# Altium schematic sheet ControllerBoard.SchDoc(ControllerBoard)
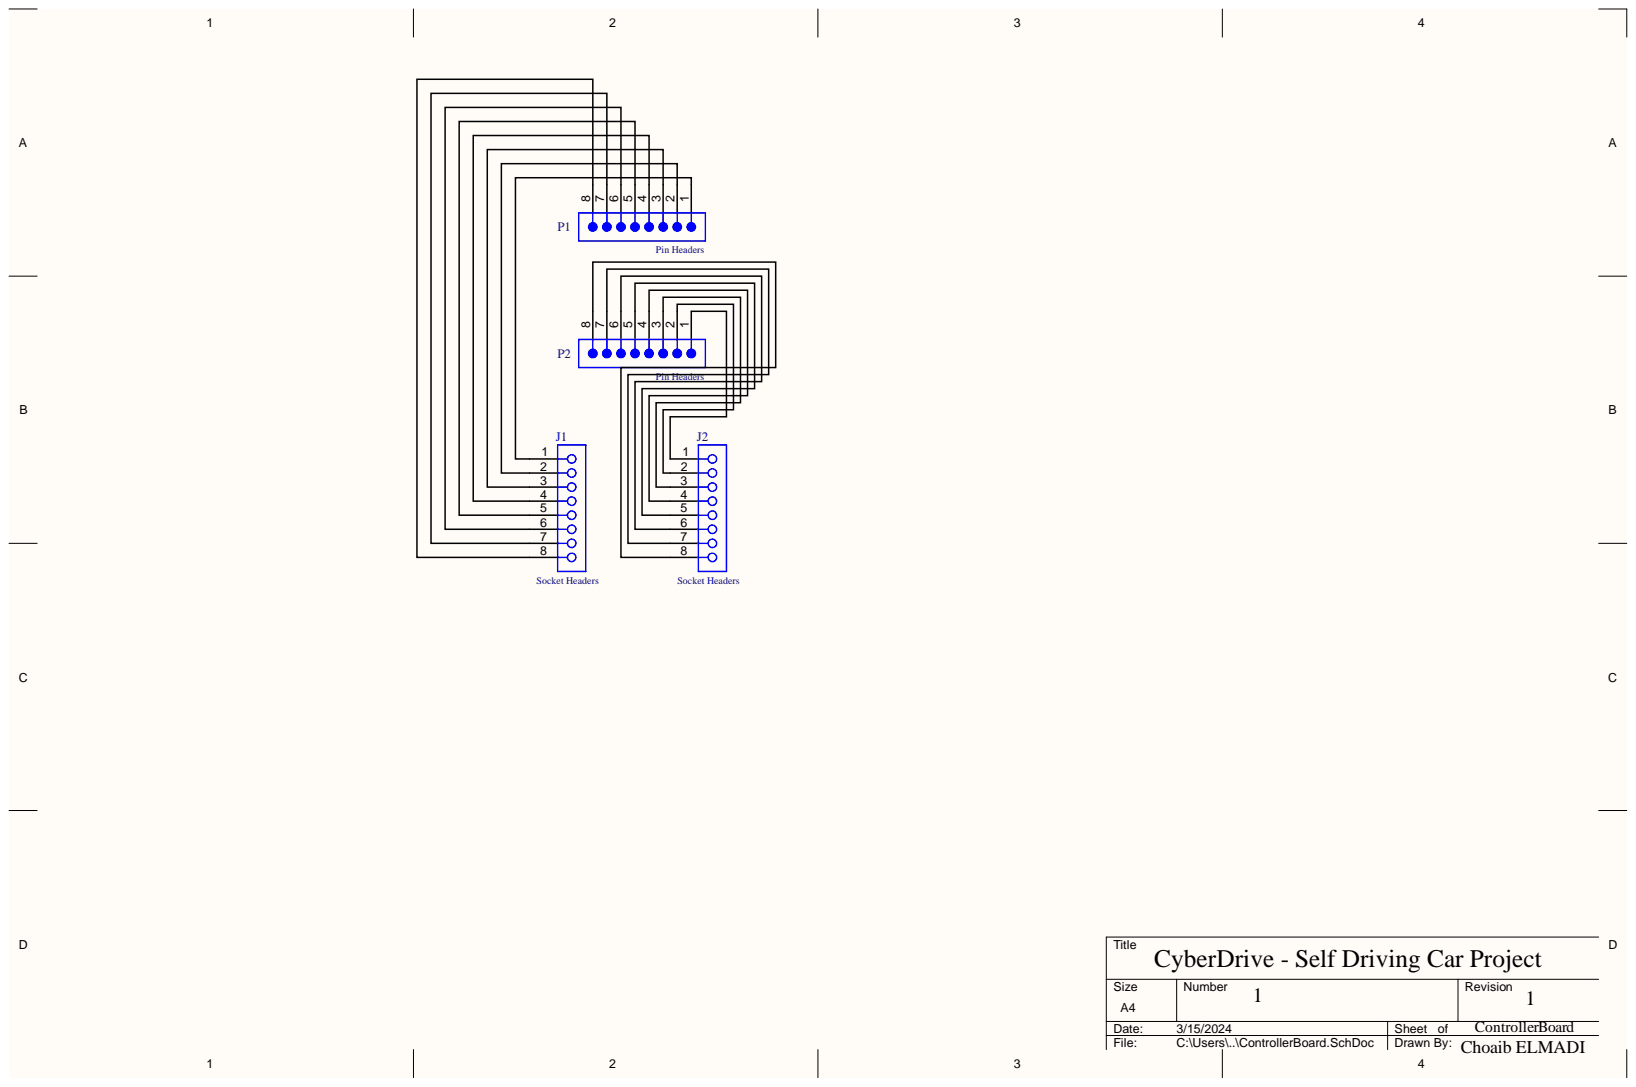
[diagram p0: ControllerBoard.SchDoc]

## Parts
J1  Socket Headers  [61300811821]
J2  Socket Headers  [61300811821]
P1  Pin Headers  [61300811121]
P2  Pin Headers  [61300811121]

## Nets
NetJ1_1: J1.1 P1.1
NetJ1_2: J1.2 P1.2
NetJ1_3: J1.3 P1.3
NetJ1_4: J1.4 P1.4
NetJ1_5: J1.5 P1.5
NetJ1_6: J1.6 P1.6
NetJ1_7: J1.7 P1.7
NetJ1_8: J1.8 P1.8
NetJ2_1: J2.1 P2.1
NetJ2_2: J2.2 P2.2
NetJ2_3: J2.3 P2.3
NetJ2_4: J2.4 P2.4
NetJ2_5: J2.5 P2.5
NetJ2_6: J2.6 P2.6
NetJ2_7: J2.7 P2.7
NetJ2_8: J2.8 P2.8

## Library data of the parts
J1 J2 = CMP-1502-01327-2: NexarPartId: 61924723; Contact Resistance: 20mΩ; Current Rating: 3A; Gender: Female; Operating Supply Voltage: 250V; Component Kind: Standard; Lead Pitch: 2.54mm; Library Name: Altium Content Vault; Number of Pins: 8; Orientation: Vertical; RoHS: Non-Compliant
P1 P2 = CMP-1502-01076-2: NexarPartId: 32855533; Connector Type: Male Pin; Contact Resistance: 20mΩ; Current Rating: 3A; Gender: Male; Number of Contacts: 8; Operating Supply Voltage: 250V; Pitch: 2.54mm; Color: Black; Component Kind: Standard; Contact Material: Copper; Contact Mating Length: 5.9944mm; Contact Plating: Gold; Height: 8.54mm; Lead Pitch: 2.54mm; Library Name: Altium Content Vault; Max Operating Temperature: 105°C; Min Operating Temperature: -40°C; Mount: Through Hole; Number of Pins: 8; Number of Positions: 8; Number of Rows: 1; Orientation: Vertical; Packaging: Bulk; REACH SVHC: Unknown; RoHS: Compliant; Termination: Solder; Voltage Rating: 250V
